# Altium schematic sheet HDMI.SchDoc
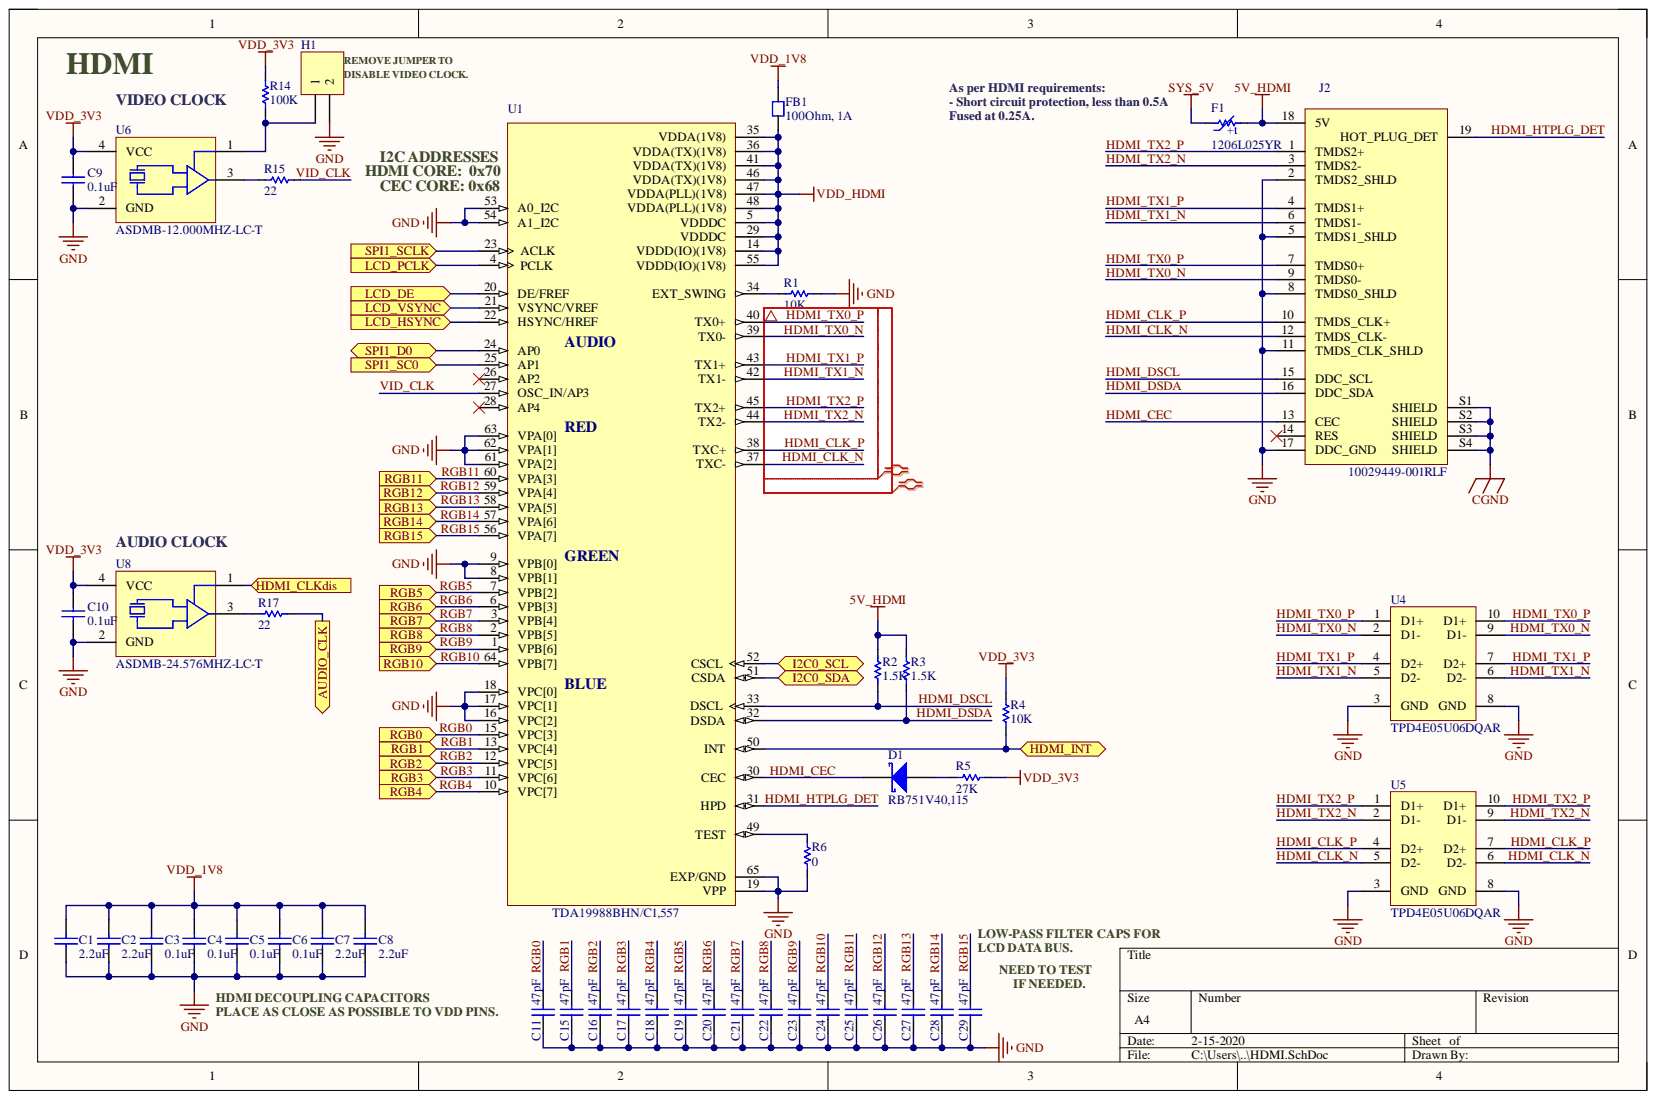
[diagram p0: HDMI.SchDoc]

## Parts
C1  2.2uF  [CAP_0603]
C2  2.2uF  [CAP_0603]
C3  0.1uF
C4  0.1uF
C5  0.1uF
C6  0.1uF
C7  2.2uF  [CAP_0603]
C8  2.2uF  [CAP_0603]
C9  0.1uF  [CAP_0603]
C10  0.1uF  [CAP_0603]
C11  47pF  [CAP_0603]
C15  47pF  [CAP_0603]
C16  47pF  [CAP_0603]
C17  47pF  [CAP_0603]
C18  47pF  [CAP_0603]
C19  47pF  [CAP_0603]
C20  47pF  [CAP_0603]
C21  47pF  [CAP_0603]
C22  47pF  [CAP_0603]
C23  47pF  [CAP_0603]
C24  47pF  [CAP_0603]
C25  47pF  [CAP_0603]
C26  47pF  [CAP_0603]
C27  47pF  [CAP_0603]
C28  47pF  [CAP_0603]
C29  47pF  [CAP_0603]
D1  RB751V40,115  [SOD-323]
F1  1206L025YR  [RES_1206]
FB1  100Ohm, 1A  [CAP_0603]
H1  02p  [HEADER_2X1_2.54MM]  (value not drawn)
J2  10029449-001RLF  [10029449-001RLF]
R1  10K  [RES_0603]
R2  1.5K  [RES_0603]
R3  1.5K  [RES_0603]
R4  10K  [RES_0603]
R5  27K  [RES_0603]
R6  0  [RES_0603]
R14  100K  [RES_0603]
R15  22  [RES_0603]
R17  22  [RES_0603]
U1  TDA19988BHN/C1,557  [HVQFN-64_9x9MM]
U4  TPD4E05U06DQAR  [USON-10_2.5X1MM]
U5  TPD4E05U06DQAR  [USON-10_2.5X1MM]
U6  ASDMB-12.000MHZ-LC-T  [ABRACON_4SMD_QFN]
U8  ASDMB-24.576MHZ-LC-T  [ABRACON_4SMD_QFN]

## Ports
AUDIO_CLK, HDMI_CLKdis, HDMI_INT, I2C0_SCL, I2C0_SDA, LCD_DE, LCD_HSYNC, LCD_PCLK, LCD_VSYNC, RGB0, RGB1, RGB2, RGB3, RGB4, RGB5, RGB6, RGB7, RGB8, RGB9, RGB10, RGB11, RGB12, RGB13, RGB14, RGB15, SPI1_D0, SPI1_SC0, SPI1_SCLK

## Nets
5V_HDMI: F1.2 J2.18(5V) R2.2 R3.2
CGND: J2.S1(SHIELD) J2.S2(SHIELD) J2.S3(SHIELD) J2.S4(SHIELD)
GND: C1.1 C2.1 C3.1 C4.1 C5.1 C6.1 C7.1 C8.1 C9.1 C10.1 C11.1 C15.1 C16.1 C17.1 C18.1 C19.1 C20.1 C21.1 C22.1 C23.1 C24.1 C25.1 C26.1 C27.1 C28.1 C29.1 H1.2 J2.2(TMDS2_SHLD) J2.5(TMDS1_SHLD) J2.8(TMDS0_SHLD) J2.11(TMDS_CLK_SHLD) J2.17(DDC_GND) R1.1 R6.1 U1.8(VPB[1]) U1.9(VPB[0]) U1.16(VPC[2]) U1.17(VPC[1]) U1.18(VPC[0]) U1.19(VPP) U1.53(A0_I2C) U1.54(A1_I2C) U1.61(VPA[2]) U1.62(VPA[1]) U1.63(VPA[0]) U1.65(EXP/GND) U4.3(GND) U4.8(GND) U5.3(GND) U5.8(GND) U6.2(GND) U8.2(GND)
SYS_5V: F1.1
VDD_1V8: C1.2 C2.2 C3.2 C4.2 C5.2 C6.2 C7.2 C8.2 FB1.2
VDD_3V3: C9.2 C10.2 R4.2 R5.2 R14.2 U6.4(VCC) U8.4(VCC)
VDD_HDMI: FB1.1 U1.5(VDDDC) U1.14(VDDD(IO)(1V8)) U1.29(VDDDC) U1.35(VDDA(1V8)) U1.36(VDDA(TX)(1V8)) U1.41(VDDA(TX)(1V8)) U1.46(VDDA(TX)(1V8)) U1.47(VDDA(PLL)(1V8)) U1.48(VDDA(PLL)(1V8)) U1.55(VDDD(IO)(1V8))
AUDIO_CLK: R17.2 ; port AUDIO_CLK
HDMI_CEC: D1.2 J2.13(CEC) U1.30(CEC)
HDMI_CLK_N: J2.12(TMDS_CLK-) U1.37(TXC-) U5.5(D2-) U5.6(D2-)
HDMI_CLK_P: J2.10(TMDS_CLK+) U1.38(TXC+) U5.4(D2+) U5.7(D2+)
HDMI_CLKdis: U8.1 ; port HDMI_CLKdis
HDMI_DSCL: J2.15(DDC_SCL) R2.1 U1.33(DSCL)
HDMI_DSDA: J2.16(DDC_SDA) R3.1 U1.32(DSDA)
HDMI_HTPLG_DET: J2.19(HOT_PLUG_DET) U1.31(HPD)
HDMI_INT: R4.1 U1.50(INT) ; port HDMI_INT
HDMI_TX0_N: J2.9(TMDS0-) U1.39(TX0-) U4.2(D1-) U4.9(D1-)
HDMI_TX0_P: J2.7(TMDS0+) U1.40(TX0+) U4.1(D1+) U4.10(D1+)
HDMI_TX1_N: J2.6(TMDS1-) U1.42(TX1-) U4.5(D2-) U4.6(D2-)
HDMI_TX1_P: J2.4(TMDS1+) U1.43(TX1+) U4.4(D2+) U4.7(D2+)
HDMI_TX2_N: J2.3(TMDS2-) U1.44(TX2-) U5.2(D1-) U5.9(D1-)
HDMI_TX2_P: J2.1(TMDS2+) U1.45(TX2+) U5.1(D1+) U5.10(D1+)
I2C0_SCL: U1.52(CSCL) ; port I2C0_SCL
I2C0_SDA: U1.51(CSDA) ; port I2C0_SDA
LCD_DE: U1.20(DE/FREF) ; port LCD_DE
LCD_HSYNC: U1.22(HSYNC/HREF) ; port LCD_HSYNC
LCD_PCLK: U1.4(PCLK) ; port LCD_PCLK
LCD_VSYNC: U1.21(VSYNC/VREF) ; port LCD_VSYNC
RGB0: C11.2 U1.15(VPC[3]) ; port RGB0
RGB1: C15.2 U1.13(VPC[4]) ; port RGB1
RGB2: C16.2 U1.12(VPC[5]) ; port RGB2
RGB3: C17.2 U1.11(VPC[6]) ; port RGB3
RGB4: C18.2 U1.10(VPC[7]) ; port RGB4
RGB5: C19.2 U1.7(VPB[2]) ; port RGB5
RGB6: C20.2 U1.6(VPB[3]) ; port RGB6
RGB7: C21.2 U1.3(VPB[4]) ; port RGB7
RGB8: C22.2 U1.2(VPB[5]) ; port RGB8
RGB9: C23.2 U1.1(VPB[6]) ; port RGB9
RGB10: C24.2 U1.64(VPB[7]) ; port RGB10
RGB11: C25.2 U1.60(VPA[3]) ; port RGB11
RGB12: C26.2 U1.59(VPA[4]) ; port RGB12
RGB13: C27.2 U1.58(VPA[5]) ; port RGB13
RGB14: C28.2 U1.57(VPA[6]) ; port RGB14
RGB15: C29.2 U1.56(VPA[7]) ; port RGB15
SPI1_D0: U1.24(AP0) ; port SPI1_D0
SPI1_SC0: U1.25(AP1) ; port SPI1_SC0
SPI1_SCLK: U1.23(ACLK) ; port SPI1_SCLK
VID_CLK: R15.2 U1.27(OSC_IN/AP3)
NetD1_1: D1.1 R5.1
NetH1_1: H1.1 R14.1 U6.1
NetR1_2: R1.2 U1.34(EXT_SWING)
NetR6_2: R6.2 U1.49(TEST)
NetR15_1: R15.1 U6.3
NetR17_1: R17.1 U8.3

Not connected: J2 14(RES); U1 26(AP2) 28(AP4)

## Library data of the parts
C1 C2 C7 C8 = C0603C225M4PAC7867: CAP CER 2.2UF 16V X5R 0603; Manufacturer: KEMET; Manufacturer Part No.: C0603C104M4RACTU; Tolerance: 20%; Ceramic Type: X5R; Component Kind: Standard; ComponentLink1Description: Manufacturer; ComponentLink1URL: http://www.we-online.de; ComponentLink2Description: Datasheet; ComponentLink2URL: https://content.kemet.com/datasheets/KEM_C1002_X7R_SMD.pdf; Datasheet: https://content.kemet.com/datasheets/KEM_C1002_X7R_SMD.pdf; Mounting Technology: SMD; Package Description: 0603; Package Reference: CAP_0603; Rated Voltage: 16V; Supplier: Digi-Key; Supplier Part No.: 399-13136-1-ND; Value: 2.2uF; Library Name: OSD335-X_Devboard.SchLib
C3-C6 C9 C10 = C0603C104M4RACTU: CAP CER 0.1UF 16V X7R 0603; Manufacturer: KEMET; Tolerance: 20%; Ceramic Type: X7R; Component Kind: Standard; ComponentLink1Description: Manufacturer; ComponentLink1URL: http://www.we-online.de; ComponentLink2Description: Datasheet; ComponentLink2URL: https://content.kemet.com/datasheets/KEM_C1002_X7R_SMD.pdf; Datasheet: https://content.kemet.com/datasheets/KEM_C1002_X7R_SMD.pdf; Manufacturer Part No.: C0603C104M4RACTU; Mounting Technology: SMD; Package Description: 0603; Package Reference: CAP_0603; Rated Voltage: 16V; Supplier: Digi-Key; Supplier Part No.: 399-1099-1-ND; Value: 0.1uF; Library Name: OSD335-X_Devboard.SchLib
C11 C15-C29 = 885012006021: CAP CER 47PF 16V C0G/NP0 0603; Manufacturer: Wurth Elektronik; Rated Voltage: 16 VDC; Tolerance: 5%; Component Kind: Standard; ComponentLink1Description: Manufacturer; ComponentLink1URL: http://www.we-online.de; ComponentLink2Description: Datasheet; ComponentLink2URL: https://katalog.we-online.com/pbs/datasheet/865080345012.pdf; Manufacturer Part No.: 885012006021; Mounting Technology: SMD; Package Description: 0603; Package Reference: CAP_0603; Supplier: Digi-Key; Supplier Part No.: 732-7764-1-ND; Value: 47 pF; Library Name: OSD335-X_Devboard.SchLib
D1 = RB751V40,115: DIODE SCHOTTKY 40V 120MA SOD323; Manufacturer: Nexperia USA Inc.; Forward Voltage: 0.37V; Component Kind: Standard; Forward Current: 120mA; Manufacturer Part No.: RB751V40,115; Mounting Technology: SMD; Supplier: Digi-Key; Supplier Part No.: 1727-6301-1-ND; Library Name: OSD335-X_Devboard.SchLib
F1 = 1206L025YR: PTC RESET FUSE 16V 250MA 1206; Manufacturer: Littelfuse Inc.; Rated Current: 0.25A; Component Kind: Standard; Datasheet: https://www.littelfuse.com/~/media/electronics/datasheets/resettable_ptcs/littelfuse_ptc_1206l_datasheet.pdf.pdf; Manufacturer Part No.: 1206L025YR; Mounting Technology: SMD; Package Description: Polymeric PTC Resettable Fuse 16V 250mA Ih Surface Mount 1206 (3216 Metric), Concave; Package Reference: CAP_1206; Supplier: Digi-Key; Supplier Part No.: F2110CT-ND; Library Name: OSD335-X_Devboard.SchLib
FB1 = MPZ1608D101BTA00: FERRITE BEAD 100 OHM 0603 1LN; Manufacturer: TDK Corporation; Component Kind: Standard; Datasheet: https://product.tdk.com/info/en/catalog/datasheets/beads_commercial_power_mpz1608_en.pdf; Manufacturer Part No.: MPZ1608D101BTA00; Mounting Technology: SMD; Package Description: 100 Ohms @ 100MHz 1 Power Line Ferrite Bead 0603 (1608 Metric) 1A 150mOhm; Package Reference: CAP_0603; Supplier: Digi-Key; Supplier Part No.: 445-2988-1-ND; Library Name: OSD335-X_Devboard.SchLib
H1 = 61300211121: CONN HEADER VERT 2POS 2.54MM; Manufacturer: Wurth Elektronik; Component Kind: Standard; ComponentLink1Description: Manufacturer; ComponentLink1URL: http://www.we-online.de; ComponentLink2Description: Datasheet; ComponentLink2URL: http://katalog.we-online.de/em/datasheet/6130xx11121.pdf; Datasheet: https://www.we-online.de/katalog/datasheet/6130xx11121.pdf; Manufacturer Part No.: 61300211121; Mounting Technology: THRU; Package Description: Header 2-pin 2.54mm 100mils; Package Reference: HEADER_2X1_2.54MM; Supplier: Wurth Elektronik; Supplier Part No.: 61300211121; Library Name: OSD335-X_Devboard.SchLib
J2 = 10029449-001RLF: CONN RCPT 1.4 HDMI 19POS SMD R/A; Manufacturer: Amphenol ICC (FCI); Component Kind: Standard; Datasheet: https://cdn.amphenol-icc.com/media/wysiwyg/files/documentation/datasheet/inputoutput/io_hdmi_receptacles.pdf; Manufacturer Part No.: 10029449-001RLF; Mounting Technology: THRU; Package Description: HDMI HDMI™ 1.4 Receptacle Connector 19 Position Surface Mount, Right Angle; Through Hole; Package Reference: 10029449-001RLF; Supplier: Digi-Key; Supplier Part No.: 609-1010-1-ND; Library Name: OSD335-X_Devboard.SchLib
R1 R4 = RC0603FR-0710KL: RES SMD 10K OHM 1% 1/10W 0603; Manufacturer: Yageo; Component Kind: Standard; ComponentLink1Description: Manufacturer Link; ComponentLink1URL: http://www.vishay.com/company/brands/dale/; ComponentLink2Description: Datasheet; ComponentLink2URL: http://www.vishay.com/docs/20035/dcrcwe3.pdf; Datasheet: https://www.yageo.com/upload/media/product/productsearch/datasheet/rchip/PYu-RC_Group_51_RoHS_L_10.pdf; Date: 2020; Manufacturer Part No.: RC0603FR-0710KL; Mounting Technology: SMD; Package Description: 0603; Package Reference: RES_0603; Power Rating: 1/10W; Supplier: Digikey; Supplier Part No.: 311-10.0KHRCT-ND; Tolerance: 1%; Value: 10K; Library Name: OSD335-X_Devboard.SchLib
R2 R3 = RC0603FR-071K5L: RES SMD 1.5K OHM 1% 1/10W 0603; Manufacturer: Yageo; Component Kind: Standard; ComponentLink1Description: Manufacturer Link; ComponentLink1URL: http://www.vishay.com/company/brands/dale/; ComponentLink2Description: Datasheet; ComponentLink2URL: http://www.vishay.com/docs/20035/dcrcwe3.pdf; Datasheet: https://www.yageo.com/upload/media/product/productsearch/datasheet/rchip/PYu-RC_Group_51_RoHS_L_10.pdf; Date: 2020; Manufacturer Part No.: RC0603FR-071K5L; Mounting Technology: SMD; Package Description: 0603; Package Reference: RES_0603; Power Rating: 1/10W; Supplier: Digikey; Supplier Part No.: 311-1.50KHRCT-ND; Tolerance: 1%; Value: 1.5K; Library Name: OSD335-X_Devboard.SchLib
R5 = RC0603FR-0727KL: RES SMD 27K OHM 1% 1/10W 0603; Manufacturer: Yageo; Component Kind: Standard; ComponentLink1Description: Manufacturer Link; ComponentLink1URL: http://www.vishay.com/company/brands/dale/; ComponentLink2Description: Datasheet; ComponentLink2URL: http://www.vishay.com/docs/20035/dcrcwe3.pdf; Datasheet: https://www.yageo.com/upload/media/product/productsearch/datasheet/rchip/PYu-RC_Group_51_RoHS_L_10.pdf; Date: 2020; Manufacturer Part No.: RC0603FR-0727KL; Mounting Technology: SMD; Package Description: 0603; Package Reference: RES_0603; Power Rating: 1/10W; Supplier: Digikey; Supplier Part No.: 311-27.0KHRCT-ND; Tolerance: 1%; Value: 27K; Library Name: OSD335-X_Devboard.SchLib
R6 = RC0603JR-070RL: RES SMD 0 OHM JUMPER 1/10W 0603; Manufacturer: Yageo; Component Kind: Standard; ComponentLink1Description: Manufacturer Link; ComponentLink1URL: http://www.vishay.com/company/brands/dale/; ComponentLink2Description: Datasheet; ComponentLink2URL: http://www.vishay.com/docs/20035/dcrcwe3.pdf; Datasheet: https://www.yageo.com/upload/media/product/productsearch/datasheet/rchip/PYu-RC_Group_51_RoHS_L_10.pdf; Date: 2020; Manufacturer Part No.: RC0603JR-070RL; Mounting Technology: SMD; Package Description: 0603; Package Reference: RES_0603; Power Rating: 1/10W; Supplier: Digikey; Supplier Part No.: 311-0.0GRCT-ND; Value: 0; Library Name: OSD335-X_Devboard.SchLib
R14 = RC0603FR-07100KL: RES SMD 100K OHM 1% 1/10W 0603; Manufacturer: Yageo; Component Kind: Standard; ComponentLink1Description: Manufacturer Link; ComponentLink1URL: http://www.vishay.com/company/brands/dale/; ComponentLink2Description: Datasheet; ComponentLink2URL: http://www.vishay.com/docs/20035/dcrcwe3.pdf; Datasheet: https://www.yageo.com/upload/media/product/productsearch/datasheet/rchip/PYu-RC_Group_51_RoHS_L_10.pdf; Date: 2020; Manufacturer Part No.: RC0603FR-07100KL; Mounting Technology: SMD; Package Description: 0603; Package Reference: RES_0603; Power Rating: 1/10W; Supplier: Digikey; Supplier Part No.: 311-100KHRCT-ND; Tolerance: 1%; Value: 100K; Library Name: OSD335-X_Devboard.SchLib
R15 R17 = RC0603JR-0722RL: RES SMD 22 OHM 5% 1/10W 0603; Manufacturer: Yageo; Component Kind: Standard; ComponentLink1Description: Manufacturer Link; ComponentLink1URL: http://www.vishay.com/company/brands/dale/; ComponentLink2Description: Datasheet; ComponentLink2URL: http://www.vishay.com/docs/20035/dcrcwe3.pdf; Datasheet: https://www.yageo.com/upload/media/product/productsearch/datasheet/rchip/PYu-RC_Group_51_RoHS_L_10.pdf; Date: 2020; Manufacturer Part No.: RC0603JR-0722RL; Mounting Technology: SMD; Package Description: 0603; Package Reference: RES_0603; Power Rating: 1/10W; Supplier: Digikey; Supplier Part No.: 311-22GRCT-ND; Tolerance: 5%; Value: 22; Library Name: OSD335-X_Devboard.SchLib
U1 = TDA19988BHN: IC VIDEO HDMI TRANS 64HVQFN; Manufacturer: NXP USA Inc.; Component Kind: Standard; ComponentLink1Description: Datasheet; ComponentLink1URL: https://media.digikey.com/pdf/Data%20Sheets/NXP%20PDFs/TDA19988_DS_21_july_2011.pdf; Manufacturer Part No.: TDA19988BHN/C1,557; Mounting Technology: SMD; Package Description: Video  IC   64-HVQFN (9x9) Package; Package Reference: HVQFN-64_9x9MM; Supplier: Digi-Key; Supplier Part No.: 568-12059-ND; Library Name: OSD335-X_Devboard.SchLib
U4 U5 = TPD4E05U06DQAR: TVS DIODE 5.5V 14V 10SON; Manufacturer: Texas Instruments; Component Kind: Standard; Datasheet: https://www.ti.com/lit/ds/symlink/tpd4e05u06.pdf; Manufacturer Part No.: TPD4E05U06DQAR; Mounting Technology: SMD; Package Description: 14V Clamp 2.5A (8/20µs) Ipp Tvs Diode Surface Mount 10-USON (2.5x1); Package Reference: USON-10; Supplier: Digi-Key; Supplier Part No.: 296-35765-1-ND; Library Name: OSD335-X_Devboard.SchLib
U6 = ASDMB-12.000MHZ-LC-T: MEMS OSC XO 12.0000MHZ LVCMOS; Manufacturer: Abracon LLC; Component Kind: Standard; Datasheet: https://abracon.com/Oscillators/ASDMB.pdf; Manufacturer Part No.: ASDMB-12.000MHZ-LC-T; Mounting Technology: SMD; Package Description: QFN-4, ABRACON 4-SMD; Package Reference: ABRACON_4SMD_QFN; Supplier: Digi-Key; Supplier Part No.: 535-11724-1-ND; Library Name: OSD335-X_Devboard.SchLib
U8 = ASDMB-24.576MHZ-LC-T: MEMS OSC XO 24.5760MHZ LVCMOS; Manufacturer: Abracon LLC; Component Kind: Standard; Datasheet: https://abracon.com/Oscillators/ASDMB.pdf; Manufacturer Part No.: ASDMB-24.576MHZ-LC-T; Mounting Technology: SMD; Package Description: QFN-4, ABRACON 4-SMD; Package Reference: ABRACON_4SMD_QFN; Supplier: Digi-Key; Supplier Part No.: 535-11729-1-ND; Library Name: OSD335-X_Devboard.SchLib
